# Altium schematic sheet Main.SchDoc(Main) (continued)

## Library data of the parts
C1 = CMP-13271-002758-1: Cap Ceramic 100nF 10V X7R ±10% SMD 0402 +125°C Paper T/R; Manufacturer: KEMET; Manufacturer Part Number: C0402C104K4RAC-TU; Dissipation Factor: 3.5%; Multilayer: Yes; Voltage: 16V; Capacitance: 100nF; Capacitor Type: Ceramic; Case Code (Imperial): 0402; Case Code (Metric): 1005; Case/Package: 0402; Category: Ceramic Capacitors; Component Kind: Standard; ComponentLink1Description: https://datasheet.ciiva.com:443/pdfs/VipMasterIC/IC/SEMS/SEMS-S-A0008523501/SEMS-S-A0008523592-1.pdf?src-supplier=IHS+Markit; ComponentLink1URL: https://datasheet.ciiva.com:443/pdfs/VipMasterIC/IC/SEMS/SEMS-S-A0008523501/SEMS-S-A0008523592-1.pdf?src-supplier=IHS+Markit; ComponentLink2Description: https://datasheet.ciiva.com/25744/cl05b104kp5nnnd-25744228.pdf?src-supplier=Digikey; ComponentLink2URL: https://datasheet.ciiva.com/25744/cl05b104kp5nnnd-25744228.pdf?src-supplier=Digikey; ComponentLink3Description: https://datasheet.ciiva.com/26838/getdatasheetpartid-355277-26838213.pdf?src-supplier=Verical; ComponentLink3URL: https://datasheet.ciiva.com/26838/getdatasheetpartid-355277-26838213.pdf?src-supplier=Verical; Depth: 0.5mm; Dielectric: X7R; Dielectric Material: Ceramic; Height: 0.5mm; JESD-609 Code: e3; Length: 1mm; Library Name: Altium Content Vault; Max Operating Temperature: 125°C; Min Operating Temperature: -55°C; Mount: Surface Mount; Mounting Technology: Surface Mount; Package Quantity: 50000; Package Shape: Rectangular; Package Style: SMTMeter; Packaging: Tape and Reel; Pins: 2; Pricing: 1=0.1, 10=0.032, 50=0.0182, 100=0.0141, 500=0.0106, 1000=0.00833, 2500=0.00758, 5000=0.00697 (USD); Radiation Hardening: No; Rated DC Voltage (URdc): 10V; Reach SVHC Compliant: No; RoHS: Yes; RoHS Compliant: Yes; Series: C; Stock: 3965396; Supplier: Digikey; Supplier Part Number: 399-C0402C104K4RAC7867CT-ND; Temperature Characteristics Code: X7R; Terminal Finish: Nickel; Termination: SMD/SMT; Thickness: 0.022inch; Tolerance: 10%; Tolerance  (fill in as +-): 10%; Tolerance (fill in as +-): 10%; Value: 100nF; Voltage Rating: 10V; Voltage Rating (DC): 10V; Width: 0.02inch
C2-C5 C8 C9 C14-C18 C20-C27 C30-C35 = CMP-13271-002758-1: Cap Ceramic 100nF 10V X7R ±10% SMD 0402 +125°C Paper T/R; Manufacturer: KEMET; Manufacturer Part Number: C0402C104K4RAC-TU; Dissipation Factor: 3.5%; Voltage: 16V; Capacitance: 100nF; Case Code (Imperial): 0402; Case Code (Metric): 1005; Case/Package: 0402; Category: Ceramic Capacitors; Component Kind: Standard; Depth: 0.5mm; Dielectric: X7R; Dielectric Material: Ceramic; Height: 0.5mm; Length: 1mm; Library Name: Altium Content Vault; Max Operating Temperature: 125°C; Min Operating Temperature: -55°C; Mount: Surface Mount; Package Quantity: 50000; Packaging: Tape and Reel; Pins: 2; Pricing: 1=0.1, 10=0.032, 50=0.0182, 100=0.0141, 500=0.0106, 1000=0.00833, 2500=0.00758, 5000=0.00697 (USD); Radiation Hardening: No; Reach SVHC Compliant: No; RoHS: Yes; Series: C; Stock: 3965396; Supplier: Digikey; Supplier Part Number: 399-C0402C104K4RAC7867CT-ND; Termination: SMD/SMT; Thickness: 0.022inch; Tolerance: 10%; Value: 100nF; Voltage Rating: 10V; Voltage Rating (DC): 10V; Width: 0.02inch
C6 C12 C13 C19 C28 = CMP-14477-004104-2: Ceramic Capacitor General Use 4.7uF ±20% 10V X5R 0402; Manufacturer: Taiyo Yuden; Manufacturer Part Number: LMK105BBJ475MVLF; Material: 4241ConductorMaterial; Capacitance: 4.7uF; Case Code (Imperial): 0402; Case Code (Metric): 1005; Case/Package: 0402; Category: Ceramic Capacitors; Component Kind: Standard; ComponentLink1Description: https://datasheet.ciiva.com/24462/423lmk105bbj475mvlf-24462535.pdf?src-supplier=Onlinecomponents.com; ComponentLink1URL: https://datasheet.ciiva.com/24462/423lmk105bbj475mvlf-24462535.pdf?src-supplier=Onlinecomponents.com; ComponentLink2Description: https://datasheet.ciiva.com/18697/mlcc-all-e-18697686.pdf?src-supplier=Digikey; ComponentLink2URL: https://datasheet.ciiva.com/18697/mlcc-all-e-18697686.pdf?src-supplier=Digikey; ComponentLink3Description: https://datasheet.ciiva.com/18697/mlcc-all-e-18697685.pdf?src-supplier=Digikey; ComponentLink3URL: https://datasheet.ciiva.com/18697/mlcc-all-e-18697685.pdf?src-supplier=Digikey; Construction: Flat; Dielectric: X5R; Halogen Free: Halogen Free; Height: 0.5mm; Length: 1mm; Library Name: Altium Content Vault; Max Operating Temperature: 85°C; Min Operating Temperature: -55°C; Mount: Surface Mount; Mounting Technology: Surface Mount; Package Quantity: 10000; Packaging: Tape and Reel; Pricing: 1=0.19, 10=0.12, 50=0.076, 100=0.0607, 500=0.04386, 1000=0.03879, 2500=0.03711, 5000=0.03373 (USD); Resistor Type: Ceramic; RoHS: Yes; RoHS Compliant: Yes; Series: M; Stock: 753964; Supplier: Digikey; Supplier Part Number: 587-3408-1-ND; Termination: SMD/SMT; Thickness: 0.022inch; Tolerance: 20%; Value: 4.7uF; Voltage Rating: 10V; Voltage Rating (DC): 10V; Weight: 2.3E-05oz; Width: 0.02inch
C7 C29 = CMP-2000-05441-1: Chip Capacitor, 22 uF, +/- 20%, 10 V, -55 to 85 degC, 0603 (1608 Metric), RoHS, Tape and Reel; Manufacturer: TDK; Manufacturer Part Number: C1608X5R1A226M080AC; PCN Part Number: MLCCPartNumberChange30/Nov/2012; Capacitance: 22µF; Design Resources: 20WNon-IsolatedBuckLEDDriverUsingPLLNK460KG; Dielectric: X5R; Dielectric Material: Ceramic; Kits: 445-9014-KIT-ND-KITMLCC100PF-22UF060310-50V; Material: 4241ConductorMaterial; Online Catalog: CSeries,GeneralPurpose; Other Names: 445-9077-1; Product Training Modules: CSeriesGeneralApplicationsSEAT,CCV,andTVCLDesignToolsGuidetoReplacinganElectrolyticCapacitorwithanMLCC; Voltage - Rated: 10V; Applications: GeneralPurpose; Case Code (Imperial): 0603; Case Code (Metric): 1608; Case/Package: 0603; Category: Capacitors; Component Kind: Standard; ComponentLink1Description: https://datasheet.ciiva.com/6274/tdkmlcccaprange-6274860.pdf?src-supplier=Digikey; ComponentLink1URL: https://datasheet.ciiva.com/6274/tdkmlcccaprange-6274860.pdf?src-supplier=Digikey; ComponentLink2Description: https://datasheet.ciiva.com/6274/tdkmlcccaprange-6274859.pdf?src-supplier=Digikey; ComponentLink2URL: https://datasheet.ciiva.com/6274/tdkmlcccaprange-6274859.pdf?src-supplier=Digikey; ComponentLink3Description: https://datasheet.ciiva.com/6274/tdkmlcccaprange-6274861.pdf?src-supplier=Digikey; ComponentLink3URL: https://datasheet.ciiva.com/6274/tdkmlcccaprange-6274861.pdf?src-supplier=Digikey; ComponentLink4Description: https://datasheet.ciiva.com/24216/mlcc-commercial-general-en-24216575.pdf?src-supplier=Mouser; ComponentLink4URL: https://datasheet.ciiva.com/24216/mlcc-commercial-general-en-24216575.pdf?src-supplier=Mouser; Datasheet URL: http://download.siliconexpert.com/pdfs/2015/4/21/15/54/45/779/tdk_/manual/37024850441143360mlcc_commercial_general_en.pdf; Datasheet Version: Mar-15; Family: CeramicCapacitors; Features: -; Height: 0.8mm; Height - Seated (Max): -; Lead Free: Lead Free; Lead Spacing: -; Lead Style: -; Length: 1.6mm; Library Name: Altium Content Vault; Manufacturer URL: http://www.tdk.com/; Max Operating Temperature: 85°C; Min Operating Temperature: -55°C; Mounting Technology: Surface Mount; Mounting Type: SurfaceMount,MLCC; Operating Temperature: -55degC~85degC; Package / Case: 0603(1608Metric); Package Description: 2-Pin Surface Mount Device, Body 1.6 x 0.8 mm; Package Quantity: 4000; Package Reference: 603; Packaging: CutTape(CT); Pricing: 1=0.33, 10=0.219, 50=0.1612, 100=0.1312, 500=0.1036, 1000=0.08748 (USD); Ratings: -; Reach SVHC Compliant: No; RoHS: Yes; RoHS Compliant: Yes; RoHS Information: RoHSCertificate-MLCC; Series: C; Size / Dimension: 0.063"Lx0.031"W(1.60mmx0.80mm); Standard Package: 1; Stock: 1433584; Supplier: Digikey; Supplier Part Number: 445-9077-1-ND; Temperature Coefficient: X5R; Termination: SMD/SMT; Thickness (Max): 0.035"(0.90mm); Tolerance: ±20%; Value: 22uF; Voltage Rating: 10V; Voltage Rating (DC): 10V; Weight: 7.1E-05oz; Width: 0.8mm
C10 C11 = CMP-03020-019954-1: CAP CER 15PF 100V C0G/NP0 0402; Manufacturer: KEMET; Manufacturer Part Number: CBR04C150F1GAC; Material: Ceramic; Temperature Characteristic: C0G; Temperature Coefficient: 30ppm/°C; Tolerance: 0.01; Automotive: No; Capacitance: 15 pF; Category: Cap; Component Kind: Standard; ComponentLink2Description: Datasheet; ComponentLink2URL: https://content.kemet.com/datasheets/KEM_C1030_CBR_SMD.pdf; Device Class L1: Passive Components; Device Class L2: Capacitors; Device Class L3: Ceramic Capacitors; Digikey Description: CAP CER 15PF 100V C0G/NP0 0402; Height: 0.55mm; Ipc Land Pattern Name: CAPC10050X50; Lead Free: Yes; Library Name: Altium Content Vault; Mouser Description: Multilayer Ceramic Capacitors MLCC - SMD/SMT 100volts 15pF C0G 0402 1% Tol RF; Octopart: https://octopart.com/cbr04c150f1gac-kemet-30609229; Package: CBR04C; RoHS: TRUE; Temperature: -55°C to +125°C; Temperature Range High: +125°C; Temperature Range Low: -55°C; Value: 15 pF; Voltage Rating: 100 V
D1 D2 = CMP-44529-000024-1: LED GREEN CLEAR CHIP SMD; Manufacturer: Kingbright; Dominant Wavelength: 525nm; Forward Current: 20mA; Forward Voltage: 3.3V; Lens Color: Colorless; Luminous Intensity: 350mcd; Viewing Angle: 120°; Case Code (Imperial): 0603; Case Code (Metric): 1608; Case/Package: 0603; Category: LEDs; Color: Green; Component Kind: Standard; ComponentLink1Description: https://datasheet.ciiva.com/16422/apt1608zgck-262051-16422477.pdf?src-supplier=Mouser; ComponentLink1URL: https://datasheet.ciiva.com/16422/apt1608zgck-262051-16422477.pdf?src-supplier=Mouser; ComponentLink2Description: https://datasheet.ciiva.com/26292/apt1608zgck-26292289.pdf?src-supplier=Digikey; ComponentLink2URL: https://datasheet.ciiva.com/26292/apt1608zgck-26292289.pdf?src-supplier=Digikey; ComponentLink3Description: https://datasheet.ciiva.com/29562/apt1608zgck-29562277.pdf?src-supplier=Digikey; ComponentLink3URL: https://datasheet.ciiva.com/29562/apt1608zgck-29562277.pdf?src-supplier=Digikey; Height: 0.75mm; Illumination Color: Green; Lead Free: Lead Free; Length: 1.6mm; Lens Transparency: Clear; Library Name: Altium Content Vault; Manufacturer Part Number: APT1608ZGCK; Max Operating Temperature: 85°C; Min Operating Temperature: -40°C; Mount: Surface Mount; Mounting Technology: Surface Mount; Package Quantity: 1; Packaging: Tape and Reel; Pricing: 5=0.3043, 50=0.2442, 150=0.2184, 500=0.1863, 2000=0.1719, 4000=0.1633 (USD); RoHS: Yes; RoHS Compliant: Yes; Series: HELI2; Stock: 1770; Supplier: LCSC; Supplier Part Number: C5554158; Test Current: 20mA; Width: 0.8mm
D3 = CMP-1063-00285-1: Transient Voltage Suppressor, Micro-Packaged Diode for ESD Protection, 2-Pin X3DFN, Pb-Free, Tape and Reel; Manufacturer: On Semiconductor; C Max (pF): 0.55; Direction: Unidirectional; IR Max (uA): 0.05; Number of Lines: 1; V(BR) Min (V): 5; VRWM Max (V): 3.3; Component Kind: Standard; ComponentLink1Description: Manufacturer URL; ComponentLink1URL: http://www.onsemi.com/; ComponentLink2Description: Datasheet; ComponentLink2URL: http://www.onsemi.cn/pub_link/Collateral/ESD7381-D.PDF; ComponentLink3Description: Package Specification; ComponentLink3URL: http://www.onsemi.cn/pub_link/Collateral/152AF.PDF; DatasheetVersion: Rev. 4; Library Name: Altium Content Vault; Mounting Technology: Surface Mount; PackageDescription: 2-Pin Small Outline No-Lead, Body 0.62 x 0.32 mm, Pitch 0.355 mm; PackageReference: X3DFN-2-152AF-01; PackageVersion: Rev. O, 04/2011; Packing: Tape and Reel; PartNumber: ESD7381MUT5G; RoHS: Pb-Free
FB1-FB4 = CMP-0686-00284-4: Chip Ferrite Bead, 0402, 80Ω @ 100MHz, 0.07Ω, 25%, 1.5A; Manufacturer: Murata; DC Resistance (DCR): 70mR; Impedance: 80R; Number of Circuits: 1; Case Code (Imperial): 0402; Case Code (Metric): 1005; Case/Package: 0402; Category: Ferrite Beads and Chips; Component Kind: Standard; ComponentLink1Description: https://datasheet.ciiva.com/41449/001172208-da-01-en-drossel-0402-80r-1-0x0-5mm-10000st-41449497.pdf?src-supplier=Conrad; ComponentLink1URL: https://datasheet.ciiva.com/41449/001172208-da-01-en-drossel-0402-80r-1-0x0-5mm-10000st-41449497.pdf?src-supplier=Conrad; ComponentLink2Description: https://datasheet.ciiva.com/1012/l0110e-1012441.pdf?src-supplier=Digikey; ComponentLink2URL: https://datasheet.ciiva.com/1012/l0110e-1012441.pdf?src-supplier=Digikey; ComponentLink3Description: https://datasheet.ciiva.com/1012/l0110e-1012440.pdf?src-supplier=Digikey; ComponentLink3URL: https://datasheet.ciiva.com/1012/l0110e-1012440.pdf?src-supplier=Digikey; ComponentLink4Description: https://datasheet.ciiva.com/1012/l0110e-1012442.pdf?src-supplier=Digikey; ComponentLink4URL: https://datasheet.ciiva.com/1012/l0110e-1012442.pdf?src-supplier=Digikey; ComponentLink5Description: https://datasheet.ciiva.com/16420/l0110s0100blm15pgblm15pd-16420757.pdf?src-supplier=Future+Electronics; ComponentLink5URL: https://datasheet.ciiva.com/16420/l0110s0100blm15pgblm15pd-16420757.pdf?src-supplier=Future+Electronics; Current Rating: 1.5A; DC Resistance-Max: 70mR; Depth: 0.5mm; Height: 0.5mm; Lead Free: Lead Free; Length: 1mm; Library Name: Altium Content Vault; Manufacturer Part Number: BLM15PD800SN1D; Material: Ferrite; Max Current Rating: 1.5A; Max DC Current: 1.5A; Max Operating Temperature: 125°C; Min Operating Temperature: -55°C; Number of Lines: 1; Number of Pins: 2; Package Quantity: 1; Packaging: Tape and Reel; Pins: 2; Pricing: 1=0.1, 10=0.068, 25=0.0616, 50=0.0556, 100=0.0408, 250=0.02968, 500=0.0272, 1000=0.02348, 5000=0.01854 (USD); REACH SVHC: No SVHC; Reach SVHC Compliant: No; RoHS: Yes; RoHS Compliant: Yes; Series: BLM15PD; Stock: 94761; Supplier: Digikey; Supplier Part Number: 490-5202-1-ND; Termination: SMD/SMT; Test Frequency: 100MHz; Tolerance: 25%; Width: 0.5mm
J1 = 73251-1354: SMA Connector Jack, Female Socket 50 Ohms Surface Mount Solder; MF: Molex; MP: 0732511354; Check_prices: https://www.snapeda.com/parts/0732511354/Molex/view-part/?ref=eda; Component Kind: Standard; Library Name: 73251-1354.IntLib; Package: None; SnapEDA_Link: https://www.snapeda.com/parts/0732511354/Molex/view-part/?ref=snap
J2 = CMP-CON-00539-2: CONN, B2C/W, HEADER, 5-POS, 1.00MM PITCH, 2.90MM H, RA, TIN, SMT; Internal Part Number: 830-02819-00; Manufacturer: JST Sales America Inc.; Current Rating: 0.7A; Insulation: Polyamide (PA), Nylon; Number of Positions/Contacts: 5; Pitch: 0.039" (1.00mm); Rows: 1; Shrouded: Shrouded - 4 Wall; Style: Board to Cable/Wire; System: Connector; Voltage Rating: 50V; Category: Headers, Male Pins; Color: Natural; Component Kind: Standard; ComponentLink1Description: https://datasheet.ciiva.com/16217/sm05b-srss-tblfsn-16217550.pdf?src-supplier=Future+Electronics; ComponentLink1URL: https://datasheet.ciiva.com/16217/sm05b-srss-tblfsn-16217550.pdf?src-supplier=Future+Electronics; ComponentLink2Description: https://datasheet.ciiva.com/11752/0900766b8082f543-11752177.pdf?src-supplier=Rs; ComponentLink2URL: https://datasheet.ciiva.com/11752/0900766b8082f543-11752177.pdf?src-supplier=Rs; ComponentLink3Description: https://datasheet.ciiva.com/26938/getdatasheetpartid-744366-26938333.pdf?src-supplier=Verical; ComponentLink3URL: https://datasheet.ciiva.com/26938/getdatasheetpartid-744366-26938333.pdf?src-supplier=Verical; ComponentLink4Description: https://datasheet.ciiva.com/26894/getdatasheetpartid-473065-26894947.pdf?src-supplier=Verical; ComponentLink4URL: https://datasheet.ciiva.com/26894/getdatasheetpartid-473065-26894947.pdf?src-supplier=Verical; ComponentLink5Description: https://datasheet.ciiva.com/730/esr-730646.pdf?src-supplier=Digikey; ComponentLink5URL: https://datasheet.ciiva.com/730/esr-730646.pdf?src-supplier=Digikey; ComponentLink6Description: https://datasheet.ciiva.com/712/esr-712751.pdf?src-supplier=Digikey; ComponentLink6URL: https://datasheet.ciiva.com/712/esr-712751.pdf?src-supplier=Digikey; ComponentLink7Description: https://datasheet.ciiva.com/712/esr-712752.pdf?src-supplier=Digikey; ComponentLink7URL: https://datasheet.ciiva.com/712/esr-712752.pdf?src-supplier=Digikey; ComponentLink8Description: https://datasheet.ciiva.com/8052/esr-8052277.pdf?src-supplier=Element14; ComponentLink8URL: https://datasheet.ciiva.com/8052/esr-8052277.pdf?src-supplier=Element14; ComponentLink9Description: https://datasheet.ciiva.com/6905/srconnector-6905301.pdf?src-supplier=Digikey; ComponentLink9URL: https://datasheet.ciiva.com/6905/srconnector-6905301.pdf?src-supplier=Digikey; ComponentLink10Description: https://datasheet.ciiva.com/6905/srconnector-6905303.pdf?src-supplier=Digikey; ComponentLink10URL: https://datasheet.ciiva.com/6905/srconnector-6905303.pdf?src-supplier=Digikey; Connector Type: Header; Contact Finish: Tin; Contact Material: Copper Alloy; Contact Shape: Square; Contact Type: Male Pin; Datasheet URL: https://www.jst-mfg.com/product/pdf/eng/eSH.pdf?65e8c309183bb; Features: Solder Retention; Height: 0.114" (2.90mm); Max Operating Temperature: 85°C; Min Operating Temperature: -25°C; Mounting Type: Surface Mount, Right Angle; Part Status: Active; Pricing: 1=0.988, 10=0.933, 100=0.721, 500=0.632, 1000=0.534 (USD); RoHS: Yes; Series: SH/SR; Signal Pins: 5; Stock: 155342; Supplier: Newark; Supplier 1: Digikey; Supplier Part Number: 33P8181; Supplier Part Number 1: 455-SM05B-SRSS-G-TBTR-ND; Termination: Solder; Library Name: Meta RLR Altium Enterprise Server
J3 = CONN_GSB316441CEU: Conn USB 3.0 Type A PL 9 POS Solder RA SMD 9 Terminal 1 Port; Manufacturer: Amphenol Commercial; Current Rating: 1.8A; MF: Amphenol; Number of Contacts: 9; Category: USB Connectors; Check_prices: https://www.snapeda.com/parts/GSB316441CEU/Amphenol/view-part/?ref=eda; Component Kind: Standard; ComponentLink1Description: https://datasheet.ciiva.com/10945/gsb316441ceu-10945197.pdf?src-supplier=Avnet; ComponentLink1URL: https://datasheet.ciiva.com/10945/gsb316441ceu-10945197.pdf?src-supplier=Avnet; Connector Type: USB; Contact Material: Copper Alloy; Contact Plating: Gold; Manufacturer Part Number: GSB316441CEU; Mounting Technology: Surface Mount,Horizontal; MP: GSB316441CEU; Orientation: Right Angle; Package: None; Package Quantity: 1; Packaging: Tape and Reel; Pricing: 1=2.04, 10=1.775, 25=1.6996, 50=1.6618, 100=1.5863, 250=1.43524 (USD); Radiation Hardening: No; RoHS: Yes; RoHS Compliant: Yes; Series: GSB3; SnapEDA_Link: https://www.snapeda.com/parts/GSB316441CEU/Amphenol/view-part/?ref=snap; Stock: 13312; Supplier: Digikey; Supplier 1: Digikey; Supplier Part Number: GSB316441CEUCT-ND; Supplier Part Number 1: GSB316441CEUCT-ND; Termination: SMD/SMT; Library Name: LotusSound.IntLib
J4 = L829-1J1T-JM: MagJack 2.5GBASE-T | 1X1 | OG/Y LED | -40 to 85C | RoHS; MF: Bel Magnetic Solutions; Component Kind: Standard; Digi-Key_Part_Number: 507-2068-ND; DigiKey-Purchase-URL: https://snapeda.com/shop?store=DigiKey&id=2758678; Library Name: L829-1J1T-JM.IntLib; MP: L829-1J1T-JM; Package: None; SnapEDA_Link: https://www.snapeda.com/parts/L829-1J1T-JM/Bel%20Magnetic%20Solutions/view-part/2758678/?ref=snap
L1 L2 = CMP-06042-016211-1: Multilayer Type Inductor for Power Lines, 1008 (2520), 3.3μH, 20%, 0.125Ω, 1.2A; Manufacturer: Murata; Manufacturer Part Number: LQM2HPN3R3MG0L; Core Material: Ferrite; DC Resistance (DCR): 125mR; Inductance: 3.3uH; Self Resonant Frequency: 30MHz; Shielding: Shielded; Test Frequency: 1MHz; Value: 3.3uH; Case Code (Imperial): 1008; Case Code (Metric): 2520; Case/Package: 1008; Category: Fixed Inductors; Component Kind: Standard; ComponentLink1Description: https://datasheet.ciiva.com/30490/0900766b812561d9-30490184.pdf?src-supplier=Rs; ComponentLink1URL: https://datasheet.ciiva.com/30490/0900766b812561d9-30490184.pdf?src-supplier=Rs; ComponentLink2Description: https://datasheet.ciiva.com/1042/l0075s0104lqm2hp-g0-1042940.pdf?src-supplier=Digikey; ComponentLink2URL: https://datasheet.ciiva.com/1042/l0075s0104lqm2hp-g0-1042940.pdf?src-supplier=Digikey; ComponentLink3Description: https://datasheet.ciiva.com/1042/l0075s0104lqm2hp-g0-1042941.pdf?src-supplier=Digikey; ComponentLink3URL: https://datasheet.ciiva.com/1042/l0075s0104lqm2hp-g0-1042941.pdf?src-supplier=Digikey; ComponentLink4Description: https://datasheet.ciiva.com/1042/l0075s0104lqm2hp-g0-1042942.pdf?src-supplier=Digikey; ComponentLink4URL: https://datasheet.ciiva.com/1042/l0075s0104lqm2hp-g0-1042942.pdf?src-supplier=Digikey; ComponentLink5Description: https://datasheet.ciiva.com/8509/l0075s0104lqm2hp-g0-8509087.pdf?src-supplier=Avnet; ComponentLink5URL: https://datasheet.ciiva.com/8509/l0075s0104lqm2hp-g0-8509087.pdf?src-supplier=Avnet; Current Rating: 1.2A; DC Current: 1.2A; Depth: 2.2mm; Height: 0.9mm; Height - Seated (Max): 0.039inch; Inductance Tolerance: 20%; Lead Free: Lead Free; Length: 2.5mm; Library Name: Altium Content Vault; Max DC Current: 1.2A; Max Operating Temperature: 125°C; Military Standard: Not; Min Operating Temperature: -55°C; Mount: Surface Mount; Mounting Technology: Surface Mount; Package Quantity: 3000; Packaging: Tape and Reel; Pricing: 1=0.21, 10=0.171, 25=0.1576, 50=0.1444, 100=0.1274, 250=0.12344, 500=0.11032, 1000=0.0893 (USD); Radiation Hardening: No; REACH SVHC: No SVHC; Reach SVHC Compliant: No; RoHS: Yes; RoHS Compliant: Yes; Series: LQM2HP_G0; Stock: 32510; Supplier: Digikey; Supplier Part Number: 490-5115-1-ND; Termination: SMD/SMT; Tolerance: 20%; Width: 2mm
R1 R2 R7 R8 R14 R15 R21 R28 R29 R31 = CMP-2002-02814-2: RES SMD 4.7K OHM 0.1% 1/16W 0402; Manufacturer: Yageo; Manufacturer Part Number: RT0402BRD074K7L; Power Rating: 0.063W; Resistance: 4.7KΩ; Temperature Coefficient: 25 ppm/°C; Tolerance: 0.001; Value: 4.7KΩ; Voltage Rating: 50V; Automotive: No; Category: Res; Component Kind: Standard; ComponentLink2Description: Datasheet; ComponentLink2URL: http://www.yageo.com/documents/recent/PYu-RT_1-to-0.01_RoHS_L_9.pdf; Composition: Thin Film; Device Class L1: Passive Components; Device Class L2: Resistors; Device Class L3: Chip SMD Resistors; Digikey Description: RES SMD 4.7K OHM 0.1% 1/16W 0402; Footprint Url: http://www.yageo.com/exep/pages/download/literatures/PYu-R_Mount_10.pdf; Height: 0.35mm; Ipc Land Pattern Name: RESC10050X30; Lead Free: Yes; Library Name: Altium Content Vault; Mouser Description: Thin Film Resistors 1/16W 4.7K ohm .1% 25ppm; Octopart: https://octopart.com/rt0402brd074k7l-yageo-1415343; Package: RT0402; RoHS: TRUE; Series: RT; Temperature: -55°C to +155°C; Temperature Range High: +155°C; Temperature Range Low: -55°C
R3-R5 R11 R32 = CMP-26527-000128-1: 10kΩ ±1% 0.063W 0402 Thick Film Chip Resistor AEC-Q200 compliant; Manufacturer: Stackpole Electronics; Manufacturer Part Number: RMCF0402FT10K0; Max Power Dissipation: 63mW; Power Rating: 62.5mW; Resistance: 10kR; Temperature Coefficient: 100ppm/°C; Value: 10kR; Case Code (Imperial): 0402; Case Code (Metric): 1005; Case/Package: 0402; Category: Chip SMD Resistors; Component Kind: Standard; ComponentLink1Description: https://datasheet.ciiva.com/24341/sei-rmcp-24341800.pdf?src-supplier=Onlinecomponents.com; ComponentLink1URL: https://datasheet.ciiva.com/24341/sei-rmcp-24341800.pdf?src-supplier=Onlinecomponents.com; Construction: Rectangular; Contact Plating: Tin; Depth: 0.5mm; Features: Automotive AEC-Q200; Height: 0.016inch; Lead Free: Lead Free; Length: 1mm; Library Name: Altium Content Vault; Max Operating Temperature: 155°C; Military Standard: Not; Min Operating Temperature: -55°C; Mount: Surface Mount; Mounting Technology: Surface Mount; Number of Terminations: 2; Packaging: Tape and Reel; Pricing: 1=0.1, 10=0.021, 50=0.0116, 100=0.0093, 500=0.00594, 1000=0.005, 5000=0.00345 (USD); Radiation Hardening: No; Ratings: AEC-Q200; Resistor Type: Thick Film; RoHS: Yes; RoHS Compliant: Yes; Series: RMCF; Size Code: 0402; Stock: 16567212; Supplier: Digikey; Supplier Part Number: RMCF0402FT10K0CT-ND; Tolerance: 1%; Width: 0.02inch
R6 R9 R23 R26 = CMP-2002-05495-2: Thick Film Chip Resistors 0402 0Ω 0.0625W 5%; Manufacturer: Bourns; Manufacturer Part Number: CR0402-J/-000GLF; Manufacturer Series: CR0402; Max Power Dissipation: 63mW; Power Rating: 62.5mW; Resistance: 0mR; Temperature Coefficient: 500ppm/°C; Value: 0R; Voltage Rating: 50V; Case Code (Imperial): 0402; Case Code (Metric): 1005; Case/Package: 0402; Category: Chip SMD Resistors; ComponentLink1Description: https://datasheet.ciiva.com/11811/0900766b80fcdb41-11811721.pdf?src-supplier=Rs; ComponentLink1URL: https://datasheet.ciiva.com/11811/0900766b80fcdb41-11811721.pdf?src-supplier=Rs; ComponentLink2Description: https://datasheet.ciiva.com/19466/774727-19466474.pdf?src-supplier=Jameco; ComponentLink2URL: https://datasheet.ciiva.com/19466/774727-19466474.pdf?src-supplier=Jameco; ComponentLink3Description: https://datasheet.ciiva.com/7986/cr0402-7986154.pdf?src-supplier=Element14; ComponentLink3URL: https://datasheet.ciiva.com/7986/cr0402-7986154.pdf?src-supplier=Element14; ComponentLink4Description: https://datasheet.ciiva.com/9116/cr0402-9116774.pdf?src-supplier=Avnet; ComponentLink4URL: https://datasheet.ciiva.com/9116/cr0402-9116774.pdf?src-supplier=Avnet; ComponentLink5Description: https://datasheet.ciiva.com/6549/cr0402-6549983.pdf?src-supplier=Digikey; ComponentLink5URL: https://datasheet.ciiva.com/6549/cr0402-6549983.pdf?src-supplier=Digikey; ComponentLink6Description: https://datasheet.ciiva.com/30262/cr0402-30262110.pdf?src-supplier=Onlinecomponents.com; ComponentLink6URL: https://datasheet.ciiva.com/30262/cr0402-30262110.pdf?src-supplier=Onlinecomponents.com; Composition: Thick Film; Contact Plating: Tin; Depth: 0.5mm; Height: 0.35mm; Length: 1mm; Library Name: Altium Content Vault; Max Operating Temperature: 125°C; Min Operating Temperature: -55°C; Mount: Surface Mount; Mounting Technology: Surface Mount; Number of Pins: 2; Number of Terminations: 2; Package Quantity: 10000; Package Shape: Rectangular; Packaging: Tape and Reel; Pins: 2NUMBER; Pricing: 100=0.004, 1000=0.0033, 3000=0.0029, 10000=0.0026, 50000=0.0024, 100000=0.0023 (USD); Radiation Hardening: No; REACH SVHC: No SVHC; Reach SVHC Compliant: No; Resistance Tolerance: 5%; Resistor Type: Thick Film; RoHS: Yes; RoHS Compliant: Yes; Series: CR0402; Stock: 2300; Supplier: LCSC; Supplier Part Number: C203078; Technology: THICK FILM; Terminal Finish: Tin; Termination: SMD/SMT; Thickness: 356um; Tolerance: 5%; Voltage Rating (DC): 50V; Width: 0.5mm
  R26: Component Kind: Standard
R10 = CMP-1011-00702-2: Manufacturer: Bourns; Manufacturer Part Number: CR0402-FX-4992GLF; Package Height: 0.35mm; Package Length: 1mm; Package Width: 0.5mm; Power: 62.5mW; Rated Temperature: 70°C; Temperature Coefficient: 100ppm/°C; Tolerance: 1%; Value: 49.9kR; Working Voltage: 50V; Case/Package: 0402; Category: Chip SMD Resistors; Component Kind: Standard; ComponentLink1Description: https://datasheet.ciiva.com:443/pdfs/VipMasterIC/IC/BOUR/BOUR-S-A0008953887/BOUR-S-A0008953887-1.pdf?src-supplier=IHS+Markit; ComponentLink1URL: https://datasheet.ciiva.com:443/pdfs/VipMasterIC/IC/BOUR/BOUR-S-A0008953887/BOUR-S-A0008953887-1.pdf?src-supplier=IHS+Markit; ComponentLink2Description: https://datasheet.ciiva.com/5589/cr0402-5589723.pdf?src-supplier=Mouser; ComponentLink2URL: https://datasheet.ciiva.com/5589/cr0402-5589723.pdf?src-supplier=Mouser; ComponentLink3Description: https://datasheet.ciiva.com/25647/cr0402-25647303.pdf?src-supplier=Digikey; ComponentLink3URL: https://datasheet.ciiva.com/25647/cr0402-25647303.pdf?src-supplier=Digikey; ComponentLink4Description: https://datasheet.ciiva.com/29711/cr0402-29711613.pdf?src-supplier=Digikey; ComponentLink4URL: https://datasheet.ciiva.com/29711/cr0402-29711613.pdf?src-supplier=Digikey; ComponentLink5Description: https://datasheet.ciiva.com/29711/cr0402-29711614.pdf?src-supplier=Digikey; ComponentLink5URL: https://datasheet.ciiva.com/29711/cr0402-29711614.pdf?src-supplier=Digikey; ComponentLink6Description: https://datasheet.ciiva.com/9121/cr0402-9121263.pdf?src-supplier=Avnet; ComponentLink6URL: https://datasheet.ciiva.com/9121/cr0402-9121263.pdf?src-supplier=Avnet; Construction: Chip; JESD-609 Code: e3; Library Name: Altium Content Vault; Max Operating Temperature: 125°C; Min Operating Temperature: -55°C; Mounting Technology: Surface Mount; Package Shape: Rectangular; Package Style: SMTMeter; Packaging: Tape and Reel; Part Number: CR0402-FX-4992GLF; Pins: 2; Pricing: 1=0.1, 10=0.012, 50=0.007, 100=0.0056, 500=0.00356, 1000=0.003, 5000=0.00207 (USD); Reach SVHC Compliant: No; Resistor Type: FIXED RESISTOR; RoHS: Yes; RoHS Compliant: Yes; Stock: 654471; Supplier: Digikey; Supplier Part Number: CR0402-FX-4992GLFCT-ND; Technology: RUTHENIUM OXIDE; Terminal Finish: Nickel; Termination: Wraparound
R12 = CMP-1011-00736-2: Manufacturer: Vishay Dale; Manufacturer Part Number: CRCW0402100KFKTD; Package Height: 0.35mm; Package Length: 1mm; Package Width: 0.5mm; Power: 63mW; Rated Temperature: 70°C; Reference Standard: AEC-Q200; Temperature Coefficient: 100ppm/°C; Tolerance: 1%; Value: 100kR; Working Voltage: 50V; Case/Package: 0402; Category: Chip SMD Resistors; Component Kind: Standard; ComponentLink1Description: https://datasheet.ciiva.com:443/pdfs/VipMasterIC/IC/VISH/VISH-S-A0002183699/VISH-S-A0002183699-1.pdf?src-supplier=IHS+Markit; ComponentLink1URL: https://datasheet.ciiva.com:443/pdfs/VipMasterIC/IC/VISH/VISH-S-A0002183699/VISH-S-A0002183699-1.pdf?src-supplier=IHS+Markit; ComponentLink2Description: https://datasheet.ciiva.com/3883/dcrcw-3883348.pdf?src-supplier=Arrow+Electronics; ComponentLink2URL: https://datasheet.ciiva.com/3883/dcrcw-3883348.pdf?src-supplier=Arrow+Electronics; ComponentLink3Description: https://datasheet.ciiva.com/26944/getdatasheetpartid-104148-26944497.pdf?src-supplier=Verical; ComponentLink3URL: https://datasheet.ciiva.com/26944/getdatasheetpartid-104148-26944497.pdf?src-supplier=Verical; ComponentLink4Description: https://datasheet.ciiva.com/2563/dcrcw-2563010.pdf?src-supplier=Digikey; ComponentLink4URL: https://datasheet.ciiva.com/2563/dcrcw-2563010.pdf?src-supplier=Digikey; ComponentLink5Description: https://datasheet.ciiva.com/8982/dcrcw-8982555.pdf?src-supplier=Avnet; ComponentLink5URL: https://datasheet.ciiva.com/8982/dcrcw-8982555.pdf?src-supplier=Avnet; Construction: Chip; JESD-609 Code: e0; Library Name: Altium Content Vault; Max Operating Temperature: 155°C; Min Operating Temperature: -55°C; Mounting Technology: Surface Mount; Package Shape: Rectangular; Package Style: SMTMeter; Packaging: Tape and Reel; Part Number: CRCW0402100KFKTD; Pins: 2; Pricing: 1=0.15, 10=0.075, 50=0.0502, 100=0.0428, 500=0.03068, 1000=0.027, 5000=0.0207 (USD); Reach SVHC Compliant: No; Resistor Type: FIXED RESISTOR; RoHS: No; RoHS Compliant: No; Stock: 51733; Supplier: Digikey; Supplier Part Number: 541-CRCW0402100KFKTDCT-ND; Technology: METAL GLAZE/THICK FILM; Terminal Finish: Nickel; Termination: Wraparound
R13 = CMP-2002-03028-2: RES SMD 24K OHM 1% 1/16W 0402; Manufacturer: Yageo; Manufacturer Part Number: RT0402FRE0724KL; Power Rating: 0.063W; Resistance: 24KΩ; Temperature Coefficient: 50 ppm/°C; Tolerance: 0.01; Value: 24KΩ; Voltage Rating: 50V; Automotive: No; Category: Res; Component Kind: Standard; ComponentLink2Description: Datasheet; ComponentLink2URL: http://www.yageo.com/documents/recent/PYu-RT_1-to-0.01_RoHS_L_9.pdf; Composition: Thin Film; Device Class L1: Passive Components; Device Class L2: Resistors; Device Class L3: Chip SMD Resistors; Digikey Description: RES SMD 24K OHM 1% 1/16W 0402; Footprint Url: http://www.yageo.com/exep/pages/download/literatures/PYu-R_Mount_10.pdf; Height: 0.35mm; Ipc Land Pattern Name: RESC10050X30; Lead Free: Yes; Library Name: Altium Content Vault; Octopart: https://octopart.com/rt0402fre0724kl-yageo-1380387; Package: RT0402; RoHS: TRUE; Series: RT; Temperature: -55°C to +155°C; Temperature Range High: +155°C; Temperature Range Low: -55°C
R16 R17 R20 = CMP-2002-03778-1: Manufacturer: KOA Speer; Manufacturer Part Number: RK73B1ETTP473J; Package Height: 0.35mm; Package Length: 1mm; Package Width: 0.5mm; Power: 100mW; Rated Temperature: 70°C; Reference Standard: AEC-Q200; Temperature Coefficient: 200ppm/°C; Tolerance: 5%; Value: 47kR; Working Voltage: 50V; Case/Package: 0402; Category: Chip SMD Resistors; Component Kind: Standard; ComponentLink1Description: https://datasheet.ciiva.com:443/pdfs/VipMasterIC/IC/KOAS/KOAS-S-A0009586303/KOAS-S-A0009586303-1.pdf?src-supplier=IHS+Markit; ComponentLink1URL: https://datasheet.ciiva.com:443/pdfs/VipMasterIC/IC/KOAS/KOAS-S-A0009586303/KOAS-S-A0009586303-1.pdf?src-supplier=IHS+Markit; ComponentLink2Description: https://datasheet.ciiva.com/5556/rk73b-5556607.pdf?src-supplier=Mouser; ComponentLink2URL: https://datasheet.ciiva.com/5556/rk73b-5556607.pdf?src-supplier=Mouser; ComponentLink3Description: https://datasheet.ciiva.com/15218/getdatasheetpartid-72374-15218893.pdf?src-supplier=Verical; ComponentLink3URL: https://datasheet.ciiva.com/15218/getdatasheetpartid-72374-15218893.pdf?src-supplier=Verical; Construction: Rectangular; JESD-609 Code: e3; Library Name: Altium Content Vault; Max Operating Temperature: 155°C; Min Operating Temperature: -55°C; Mounting Technology: Surface Mount; Package Style: SMTMeter; Packaging: Tape and Reel; Part Number: RK73B1ETTP473J; Pins: 2; Pricing: 1=0.1, 10=0.017, 50=0.0104, 100=0.0087, 500=0.0058, 1000=0.00494, 5000=0.00355 (USD); Reach SVHC Compliant: Yes; Resistor Type: FIXED RESISTOR; RoHS: Yes; RoHS Compliant: Yes; Stock: 25570; Supplier: Digikey; Supplier Part Number: 2019-RK73B1ETTP473JCT-ND; Technology: METAL GLAZE/THICK FILM; Terminal Finish: Nickel; Termination: Wraparound
R18 R19 = CRCW04020000Z0EDHP: RES SMD 0 OHM JUMPER 1/5W 0402; Manufacturer: Vishay Dale; Database Table Name: Resistors - Surface Mount - 0402; Power (Watts): 0.2W, 1/5W; Component Kind: Standard; ComponentLink1Description: Datasheet; ComponentLink1URL: http://www.vishay.com/docs/20043/crcwhpe3.pdf; ComponentLink2Description: DigiKey Link; ComponentLink2URL: https://www.digikey.com/en/products/detail/vishay-dale/CRCW04020000Z0EDHP/2222496; Composition: Thick Film; Device Package: 0402 (1005 Metric); Features: Automotive AEC-Q200, Pulse Withstanding; Height - Seated (Max): 0.016" (0.40mm); LastUpdated: 2/9/2024 8:30:21 PM; Library Name: Celestial Altium Library - altium_library.DbLib; Lifecycle Status: Active; Minimum Order: 1; Number of Terminations: 2; Operating Temperature: -55°C ~ 155°C; Package / Case: 0402 (1005 Metric); Packaging: TapeAndReel; Part Number: CRCW04020000Z0EDHP; Part Status: Active; PartId: 3131; Price: 0.01; Ratings: AEC-Q200; Resistance: 0 Ohms; Series: CRCW-HP; Size / Dimension: 0.039" L x 0.020" W (1.00mm x 0.50mm); Supplier 1: DigiKey; Supplier Device Package: 0402; Supplier Part Number 1: 541-0.0YBCT-ND; Tolerance: Jumper; Value: 0 Ohms
R22 R34 = CMP-26527-001513-1: 1MΩ ±5% 0.063W 0402 Thick Film Chip Resistor AEC-Q200 compliant; Manufacturer: Stackpole Electronics; Manufacturer Part Number: RMCF0402JT1M00; Max Power Dissipation: 63mW; Resistance: 1MR; Temperature Coefficient: 100ppm/°C; Value: 1MR; Working Voltage: 50V; Case Code (Imperial): 0402; Case Code (Metric): 1005; Case/Package: 0402; Category: Chip SMD Resistors; ComponentLink1Description: https://datasheet.ciiva.com/26946/getdatasheetpartid-791049-26946706.pdf?src-supplier=Verical; ComponentLink1URL: https://datasheet.ciiva.com/26946/getdatasheetpartid-791049-26946706.pdf?src-supplier=Verical; Construction: Rectangular; Contact Plating: Tin; Depth: 0.5mm; Features: Automotive AEC-Q200; Height: 0.016inch; Lead Free: Lead Free; Length: 0.039inch; Library Name: Altium Content Vault; Max Operating Temperature: 155°C; Military Standard: Not; Min Operating Temperature: -55°C; Mount: Surface Mount; Mounting Technology: Surface Mount; Number of Terminations: 2; Packaging: Tape and Reel; Power Rating: 63mW; Pricing: 1=0.1, 10=0.016, 50=0.009, 100=0.0072, 500=0.00462, 1000=0.00389, 5000=0.00269 (USD); Radiation Hardening: No; Resistor Type: Thick Film; RoHS: Yes; RoHS Compliant: Yes; Series: RMCF; Stock: 22890; Supplier: Digikey; Supplier Part Number: RMCF0402JT1M00CT-ND; Technology: THICK FILM; Tolerance: 5%; Width: 0.02inch
R24 R25 = CMP-13261-004965-1: Res Thick Film 0402 330Ω 1% 0.063W ±100ppm/°C Molded SMD T/R; Manufacturer: Samsung; Manufacturer Part Number: RC1005F331CS; Max Power Dissipation: 63mW; Resistance: 330R; Value: 330R; Case Code (Imperial): 0402; Case Code (Metric): 1005; Case/Package: 0402; Category: Chip SMD Resistors; Component Kind: Standard; ComponentLink1Description: https://datasheet.ciiva.com/16481/rc-series-16481001.pdf?src-supplier=Future+Electronics; ComponentLink1URL: https://datasheet.ciiva.com/16481/rc-series-16481001.pdf?src-supplier=Future+Electronics; Composition: Thick Film; Contact Plating: Tin; Depth: 0.5mm; Features: Moisture Resistant; Height: 0.016inch; Length: 0.039inch; Library Name: Altium Content Vault; Max Operating Temperature: 155°C; Military Standard: Not; Min Operating Temperature: -55°C; Number of Terminations: 2; Package Quantity: 1; Packaging: Tape and Reel; Power Rating: 63mW; Pricing: 1=0.1, 10=0.034, 50=0.0224, 100=0.0188, 500=0.01312, 1000=0.01142, 5000=0.0085 (USD); Radiation Hardening: No; RoHS: Yes; RoHS Compliant: Yes; Series: RC; Stock: 6645; Supplier: Digikey; Supplier Part Number: 1276-3976-1-ND; Temperature Coefficient: 100ppm/°C; Tolerance: 1%; Width: 0.02inch
R27 = CMP-2002-03738-1: Manufacturer: KOA Speer; Manufacturer Part Number: RK73B1ETTP201J; Power Rating: 63mW; Temperature Coefficient: 200ppm/°C; Tolerance: 5%; Value: 200R; Voltage Rating: 50V; Case Code (Imperial): 0402; Case Code (Metric): 1005; Case/Package: 0402; Category: Chip SMD Resistors; Component Kind: Standard; ComponentLink1Description: https://datasheet.ciiva.com/27054/1876901-27054398.pdf?src-supplier=Jameco; ComponentLink1URL: https://datasheet.ciiva.com/27054/1876901-27054398.pdf?src-supplier=Jameco; ComponentLink2Description: https://datasheet.ciiva.com/15202/getdatasheetpartid-170798-15202604.pdf?src-supplier=Verical; ComponentLink2URL: https://datasheet.ciiva.com/15202/getdatasheetpartid-170798-15202604.pdf?src-supplier=Verical; ComponentLink3Description: https://datasheet.ciiva.com/5555/rk73b-5555653.pdf?src-supplier=Mouser; ComponentLink3URL: https://datasheet.ciiva.com/5555/rk73b-5555653.pdf?src-supplier=Mouser; Construction: Rectangular; Depth: 0.5mm; Height: 0.35mm; Lead Free: Lead Free; Length: 1mm; Library Name: Altium Content Vault; Max Operating Temperature: 155°C; Military Standard: MIL-R-55342F; Min Operating Temperature: -55°C; Mounting Technology: Surface Mount; Package Quantity: 10000; Packaging: Tape and Reel; Part Number: RK73B1ETTP201J; Pricing: 1=0.1, 10=0.017, 50=0.0104, 100=0.0087, 500=0.0058, 1000=0.00494, 5000=0.00355 (USD); RoHS: Yes; RoHS Compliant: Yes; Series: RK73B; Stock: 533194; Supplier: Digikey; Supplier Part Number: 2019-RK73B1ETTP201JCT-ND; Technology: THICK FILM; Termination: SMD/SMT; Voltage Rating (DC): 50V; Width: 0.5mm
R30 = CMP-2002-07182-1: Manufacturer: Yageo / Phycomp; Manufacturer Part Number: RC0402FR-1322RL; Additional Feature: LASER TRIMMABLE; Package Height: 0.35mm; Package Length: 1mm; Package Width: 0.5mm; Power: 62.5mW; Rated Temperature: 70°C; Temperature Coefficient: 100ppm/°C; Tolerance: 1%; Working Voltage: 50V; Case/Package: 0402; Category: Chip SMD Resistors; Component Kind: Standard; ComponentLink1Description: https://datasheet.ciiva.com:443/pdfs/VipMasterIC/IC/YAGO/YAGO-S-A0008145938/YAGO-S-A0008145938-1.pdf?src-supplier=IHS+Markit; ComponentLink1URL: https://datasheet.ciiva.com:443/pdfs/VipMasterIC/IC/YAGO/YAGO-S-A0008145938/YAGO-S-A0008145938-1.pdf?src-supplier=IHS+Markit; ComponentLink2Description: https://datasheet.ciiva.com/8890/pyu-rc0402-51-rohs-l-6-8890187.pdf?src-supplier=Avnet; ComponentLink2URL: https://datasheet.ciiva.com/8890/pyu-rc0402-51-rohs-l-6-8890187.pdf?src-supplier=Avnet; ComponentLink3Description: https://datasheet.ciiva.com/17423/pyu-rc0402-51-rohs-l-6-r-17423721.pdf?src-supplier=Future+Electronics; ComponentLink3URL: https://datasheet.ciiva.com/17423/pyu-rc0402-51-rohs-l-6-r-17423721.pdf?src-supplier=Future+Electronics; Construction: Chip; JESD-609 Code: e3; Library Name: Altium Content Vault; Max Operating Temperature: 155°C; Min Operating Temperature: -55°C; Mounting Technology: Surface Mount; Package Shape: Rectangular; Package Style: SMTMeter; Packaging: Tape and Reel; Part Number: RC0402FR-1322RL; Pins: 2; Pricing: 1=0.1, 10=0.015, 50=0.0088, 100=0.0073, 500=0.00488, 1000=0.00417, 5000=0.00297, 10000=0.00261 (USD); Reach SVHC Compliant: Yes; Resistor Type: FIXED RESISTOR; RoHS: Yes; RoHS Compliant: Yes; Stock: 43771; Supplier: Digikey; Supplier Part Number: 13-RC0402FR-1322RLCT-ND; Technology: METAL GLAZE/THICK FILM; Terminal Finish: Nickel; Termination: Wraparound; Value: 22R
R33 = CMP-2002-07644-1: Manufacturer: Yageo; Manufacturer Part Number: RC0402FR-0739K2L; Additional Feature: LASER TRIMMABLE; Package Height: 0.35mm; Package Length: 1mm; Package Width: 0.5mm; Power: 62.5mW; Rated Temperature: 70°C; Temperature Coefficient: 100ppm/°C; Tolerance: 1%; Value: 39.2kR; Working Voltage: 50V; Case/Package: 0402; Category: Chip SMD Resistors; Component Kind: Standard; ComponentLink1Description: https://datasheet.ciiva.com:443/pdfs/VipMasterIC/IC/YAGO/YAGO-S-A0008145938/YAGO-S-A0008145938-1.pdf?src-supplier=IHS+Markit; ComponentLink1URL: https://datasheet.ciiva.com:443/pdfs/VipMasterIC/IC/YAGO/YAGO-S-A0008145938/YAGO-S-A0008145938-1.pdf?src-supplier=IHS+Markit; ComponentLink2Description: https://datasheet.ciiva.com/7983/1018594-7983528.pdf?src-supplier=Element14; ComponentLink2URL: https://datasheet.ciiva.com/7983/1018594-7983528.pdf?src-supplier=Element14; ComponentLink3Description: https://datasheet.ciiva.com/5562/pyu-rc0402-51-rohs-l-6-r-5562837.pdf?src-supplier=Mouser; ComponentLink3URL: https://datasheet.ciiva.com/5562/pyu-rc0402-51-rohs-l-6-r-5562837.pdf?src-supplier=Mouser; ComponentLink4Description: https://datasheet.ciiva.com/2553/pyu-r-int-thick-7-2553209.pdf?src-supplier=Digikey; ComponentLink4URL: https://datasheet.ciiva.com/2553/pyu-r-int-thick-7-2553209.pdf?src-supplier=Digikey; ComponentLink5Description: https://datasheet.ciiva.com/2553/pyu-r-int-thick-7-2553210.pdf?src-supplier=Digikey; ComponentLink5URL: https://datasheet.ciiva.com/2553/pyu-r-int-thick-7-2553210.pdf?src-supplier=Digikey; ComponentLink6Description: https://datasheet.ciiva.com/2553/pyu-r-int-thick-7-2553211.pdf?src-supplier=Digikey; ComponentLink6URL: https://datasheet.ciiva.com/2553/pyu-r-int-thick-7-2553211.pdf?src-supplier=Digikey; ComponentLink7Description: https://datasheet.ciiva.com/16742/pyu-rc0402-51-rohs-l-6-r-16742220.pdf?src-supplier=Future+Electronics; ComponentLink7URL: https://datasheet.ciiva.com/16742/pyu-rc0402-51-rohs-l-6-r-16742220.pdf?src-supplier=Future+Electronics; Construction: Chip; JESD-609 Code: e3; Library Name: Altium Content Vault; Max Operating Temperature: 155°C; Min Operating Temperature: -55°C; Mounting Technology: Surface Mount; Package Shape: Rectangular; Package Style: SMTMeter; Packaging: Tape and Reel; Part Number: RC0402FR-0739K2L; Pins: 2; Pricing: 1=0.1, 10=0.015, 50=0.0088, 100=0.0073, 500=0.00488, 1000=0.00417, 5000=0.00297 (USD); Reach SVHC Compliant: Yes; Resistor Type: FIXED RESISTOR; RoHS: Yes; RoHS Compliant: Yes; Stock: 204222; Supplier: Digikey; Supplier Part Number: 311-39.2KLRCT-ND; Technology: METAL GLAZE/THICK FILM; Terminal Finish: Nickel; Termination: Wraparound
SW1 = 218-4LPST: SWITCH SLIDE DIP SPST 25MA 24V; Manufacturer: CTS Electrocomponents; Actuator Level: Flush, Recessed; Actuator Type: Slide (Standard); Database Table Name: Switches - DIP; Number of Positions: 4; Pitch: 0.050" (1.27mm), Half; Voltage Rating: 24VDC; Washable: Yes; Circuit: SPST; Component Kind: Standard; ComponentLink1Description: Datasheet; ComponentLink1URL: https://www.ctscorp.com/wp-content/uploads/218.pdf; ComponentLink2Description: DigiKey Link; ComponentLink2URL: http://digikey.com/product-detail/en/cts-electrocomponents/218-4LPST/CT2184LPST-ND/267320; Contact Finish: Gold; Contact Material: Beryllium Copper; Current Rating (Amps): 25mA; Features: Epoxy Sealed Terminals, Tape Seal; Height Above Board: 0.104" (2.65mm); LastUpdated: 1/4/2020 7:03:56 PM; Library Name: Celestial Altium Library - altium_library.DbLib; Lifecycle Status: Active; Minimum Order: 1; Mounting Type: Surface Mount; Operating Temperature: -55°C ~ 85°C; Packaging: Tube; Part Number: 218-4LPSJ; Part Status: Active; PartId: 10; Price: 1.18; Series: 218; Supplier 1: DigiKey; Supplier Part Number 1: 218-4LPSJ; Termination Style: Gull Wing
U1 U4 = NC7WV125K8X: Integrated Circuit; Manufacturer_Name: ON Semiconductor; Arrow Part Number: NC7WV125K8X; Arrow Price/Stock: https://www.arrow.com/en/products/nc7wv125k8x/on-semiconductor; Component Kind: Standard; Datasheet Link: https://www.mouser.com/datasheet/2/308/NC7WV125-1301459.pdf; Height: 0.9mm; Library Name: SamacSys.SchLib; Manufacturer_Part_Number: NC7WV125K8X; Mouser Part Number: 512-NC7WV125K8X; Mouser Price/Stock: https://www.mouser.com/Search/Refine.aspx?Keyword=512-NC7WV125K8X
U2 U3 U5 = 74LVC1G3157FZ4-7: Analog Switch Single SPDT 6-Pin X2-DFN T/R; Availability: Unavailable; Component Kind: Standard; Library Name: 74LVC1G3157FZ4-7.IntLib; MP: 74LVC1G3157FZ4-7; Package: None; Price: None
U6 = CMP-0240-00158-1: Synchronous rectification with inhibit, 1.5 A, 1.5 MHz adjustable, step-down switching regulator, 6-Pin DFN; Manufacturer: STMicroelectronics; Accuracy: 2%; Efficiency: 90%; Frequency: 1.5MHz; Max Supply Voltage: 6V; Min Input Voltage: 2.7V; Number of Outputs: 1; Output Type: Fixed,Adjustable; Output Voltage: 3.3V; Polarity: Positive; Quiescent Current: 1.5mA; Synchronous Rectifier: Yes; Case/Package: DFN; Category: Voltage Regulators - Switching; Component Kind: Standard; ComponentLink1Description: Manufacturer URL; ComponentLink1URL: http://www.st.com; ComponentLink2Description: Datasheet; ComponentLink2URL: http://www.st.com/internet/com/TECHNICAL_RESOURCES/TECHNICAL_LITERATURE/DATASHEET/CD00100211.pdf; ComponentLink3Description: https://datasheet.ciiva.com/15113/getdatasheetpartid-188384-15113793.pdf?src-supplier=Verical; ComponentLink3URL: https://datasheet.ciiva.com/15113/getdatasheetpartid-188384-15113793.pdf?src-supplier=Verical; ComponentLink4Description: https://datasheet.ciiva.com/2029/cd00100211-2029328.pdf?src-supplier=Digikey; ComponentLink4URL: https://datasheet.ciiva.com/2029/cd00100211-2029328.pdf?src-supplier=Digikey; ComponentLink5Description: https://datasheet.ciiva.com/2029/cd00100211-2029329.pdf?src-supplier=Digikey; ComponentLink5URL: https://datasheet.ciiva.com/2029/cd00100211-2029329.pdf?src-supplier=Digikey; ComponentLink6Description: https://datasheet.ciiva.com/2029/cd00100211-2029330.pdf?src-supplier=Digikey; ComponentLink6URL: https://datasheet.ciiva.com/2029/cd00100211-2029330.pdf?src-supplier=Digikey; ComponentLink7Description: https://datasheet.ciiva.com/16489/cd00100211-16489901.pdf?src-supplier=Future+Electronics; ComponentLink7URL: https://datasheet.ciiva.com/16489/cd00100211-16489901.pdf?src-supplier=Future+Electronics; ComponentLink8Description: https://datasheet.ciiva.com/4905/cd00100211-4905371.pdf?src-supplier=Mouser; ComponentLink8URL: https://datasheet.ciiva.com/4905/cd00100211-4905371.pdf?src-supplier=Mouser; DatasheetVersion: May-2009; Height: 0.95mm; Lead Free: Lead Free; Length: 3.1mm; Library Name: Altium Content Vault; Manufacturer Part Number: ST1S06PU33R; Max Operating Temperature: 125°C; Min Operating Temperature: -30°C; Mounting Technology: Surface Mount; Operating Supply Voltage: 6V; Output Current: 1.5A; Package Quantity: 1; PackageDescription: 6-Pin Plastic, Dual LLP, 3 x 3 mm Body, 0.95 mm Pitch, Exposed Pad; PackageReference: DFN6D; PackageVersion: 2008; Packaging: Tape and Reel; Pins: 6; Pricing: 1=1.46, 10=1.306, 25=1.2392, 100=1.0179, 250=0.95156, 500=0.8409, 1000=0.66387 (USD); Radiation Hardening: No; RoHS: Yes; RoHS Compliant: Yes; Series: -; Stock: 5274; Supplier: Digikey; Supplier Part Number: 497-6311-1-ND; Switching Frequency: 1.5MHz; Weight: 0.000744oz; Width: 3.1mm
U7 = IC_AX88279: Component Kind: Standard; Library Name: LotusSound.IntLib
U8 = MX25L1636EM2I-08G-TB: Integrated Circuit; Manufacturer_Name: Macronix; Component Kind: Standard; Datasheet Link: https://www.mouser.in/datasheet/2/819/MX25L1636E_2c_3V_2c_16Mb_2c_v1_3-3370913.pdf; Height: 2.16mm; Library Name: SamacSys.SchLib; Manufacturer_Part_Number: MX25L1636EM2I-08G-TB
U9 = CMP-0240-00159-1: Synchronous rectification with inhibit, 1.5 A, 1.5 MHz adjustable, step-down switching regulator, 6-Pin DFN; Component Kind: Standard; ComponentLink1Description: Manufacturer URL; ComponentLink1URL: http://www.st.com; ComponentLink2Description: Datasheet; ComponentLink2URL: http://www.st.com/internet/com/TECHNICAL_RESOURCES/TECHNICAL_LITERATURE/DATASHEET/CD00100211.pdf; DatasheetVersion: May-2009; Library Name: Altium Content Vault; PackageDescription: 6-Pin Plastic, Dual LLP, 3 x 3 mm Body, 0.95 mm Pitch, Exposed Pad; PackageReference: DFN6D; PackageVersion: 2008
Y1 = CMP-27762-002203-1: Crystal 25MHz ±20ppm 18pF SMD-2 5mm x 3.2mm; Manufacturer: Abracon; Aging: 5 PPM/FIRST YEAR; Crystal/Resonator Type: PARALLEL - FUNDAMENTAL; Drive Level: 10uW; ESR: 50R; ESR (Equivalent Series Resistance): 30R; Frequency Stability: 30ppm; Operating Frequency-Nom: 250; Case/Package: CSMD; Category: Crystals; Component Kind: Standard; ComponentLink1Description: https://datasheet.ciiva.com:443/pdfs/VipMasterIC/IC/ABRC/ABRCS03152/ABRCS03152-1.pdf?src-supplier=IHS+Markit; ComponentLink1URL: https://datasheet.ciiva.com:443/pdfs/VipMasterIC/IC/ABRC/ABRCS03152/ABRCS03152-1.pdf?src-supplier=IHS+Markit; ComponentLink2Description: https://datasheet.ciiva.com/855/abm3-855867.pdf?src-supplier=Digikey; ComponentLink2URL: https://datasheet.ciiva.com/855/abm3-855867.pdf?src-supplier=Digikey; ComponentLink3Description: https://datasheet.ciiva.com/855/abm3-855868.pdf?src-supplier=Digikey; ComponentLink3URL: https://datasheet.ciiva.com/855/abm3-855868.pdf?src-supplier=Digikey; ComponentLink4Description: https://datasheet.ciiva.com/855/abm3-855869.pdf?src-supplier=Digikey; ComponentLink4URL: https://datasheet.ciiva.com/855/abm3-855869.pdf?src-supplier=Digikey; ComponentLink5Description: https://datasheet.ciiva.com/16223/abm3-16223368.pdf?src-supplier=Future+Electronics; ComponentLink5URL: https://datasheet.ciiva.com/16223/abm3-16223368.pdf?src-supplier=Future+Electronics; ComponentLink6Description: https://datasheet.ciiva.com/7998/abm3-7998575.pdf?src-supplier=Element14; ComponentLink6URL: https://datasheet.ciiva.com/7998/abm3-7998575.pdf?src-supplier=Element14; Depth: 3.2mm; Frequency: 25MHz; Frequency Tolerance: 20ppm; Height: 0.051inch; JESD-609 Code: e4; Lead Free: Lead Free; Length: 5mm; Library Name: Altium Content Vault; Load Capacitance: 18pF; Manufacturer Part Number: ABM3-25.000MHZ-D2Y-T; Max Operating Temperature: 85°C; Min Operating Temperature: -40°C; Mount: Surface Mount; Mounting Technology: Surface Mount; Number of Pins: 2; Operating Frequency: 25 MHz; Package Quantity: 1000; Packaging: Tape and Reel; Pricing: 1=0.59, 10=0.505, 50=0.4552, 100=0.4354, 500=0.39268 (USD); REACH SVHC: Yes; Reach SVHC Compliant: Yes; Resistor Type: Ceramic; RoHS: Yes; RoHS Compliant: Yes; Series: ABM3; Series Resistance: 30R; Stock: 31136; Supplier: Digikey; Supplier Part Number: 535-10645-1-ND; Terminal Finish: Silver; Termination: SMD/SMT; Tolerance: 20ppm; Width: 3.2mm
